# Altium schematic sheet MCU_BLE_Module.SchDoc(MCU_BLE_Module)
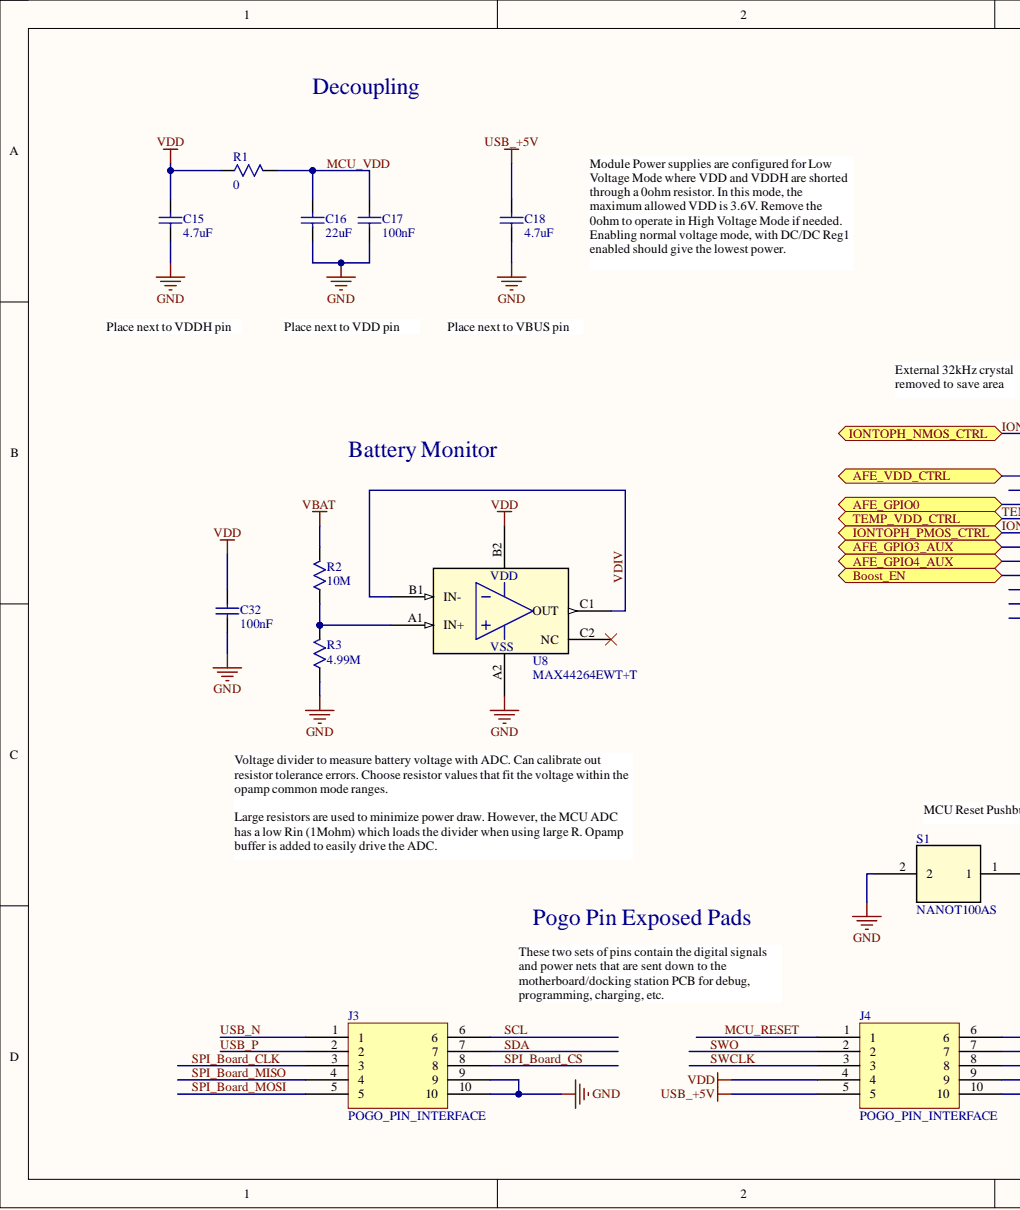
[diagram p0: MCU_BLE_Module.SchDoc, left]
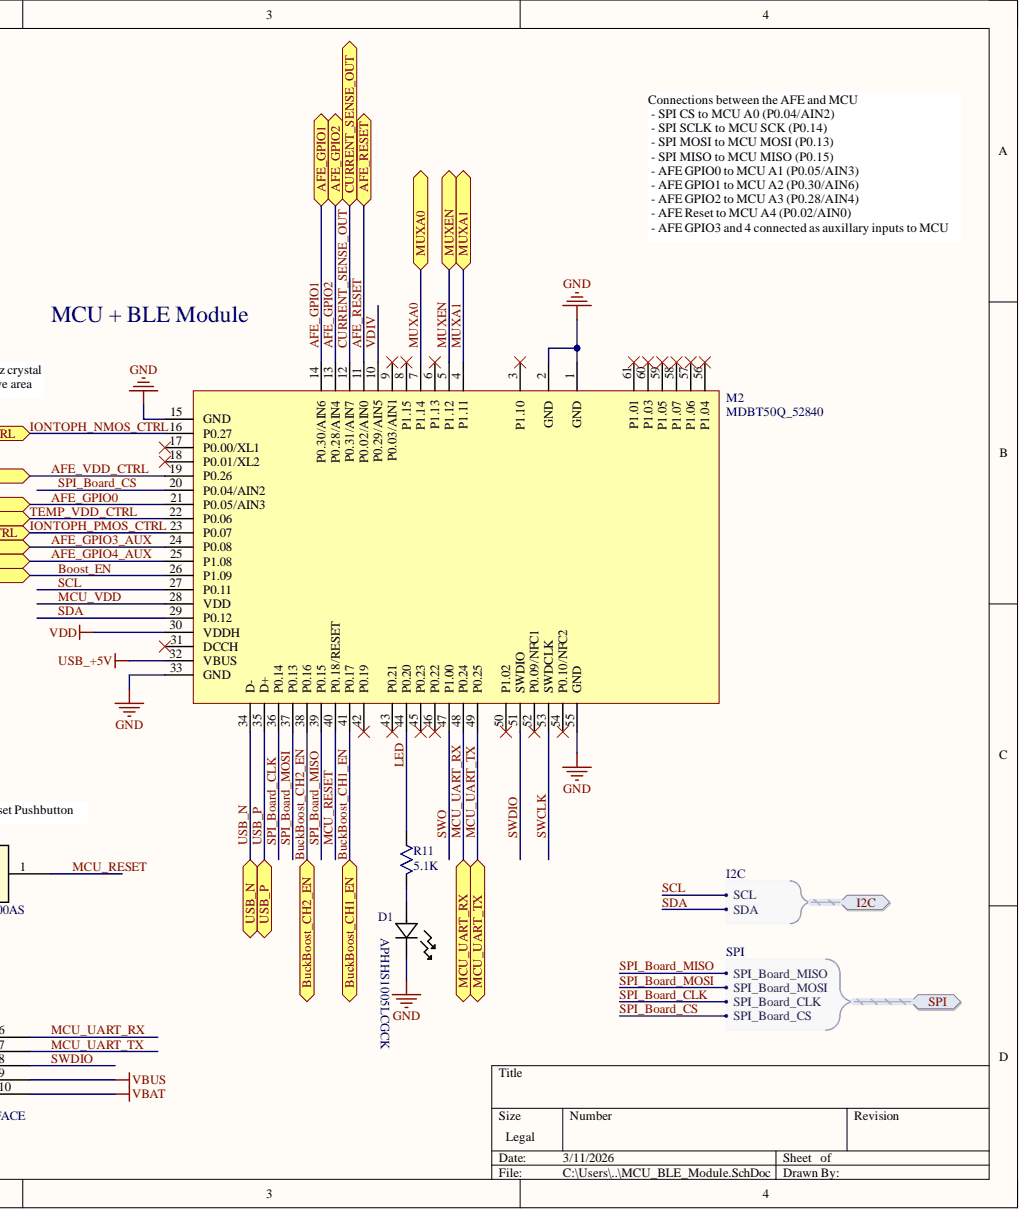
[diagram p1: MCU_BLE_Module.SchDoc, right]

## Parts
C15  value 4.7uF  [0201 cap]  (hidden comment: CAP)
C16  value 22uF  [0402-CAP]  (hidden comment: CAP)
C17  value 100nF  [0201 cap]  (hidden comment: CAP)
C18  value 4.7uF  [0201 cap]  (hidden comment: CAP)
C32  value 100nF  [0201 cap]  (hidden comment: CAP)
D1  APHHS1005LCGCK  [0402-LED]
J3  POGO_PIN_INTERFACE  [POGO_PIN_INTERFACE_10pin]
J4  POGO_PIN_INTERFACE  [POGO_PIN_INTERFACE_10pin]
M2  MDBT50Q_52840  [MDBT50Q]
R1  value 0  [0201 res]  (hidden comment: RES)
R2  value 10M  [0402-RES]  (hidden comment: RES)
R3  value 4.99M  [0402-RES]  (hidden comment: RES)
R11  value 5.1K  [0201 res]  (hidden comment: RES)
S1  NANOT100AS  [NANOT100AS_CNK-M]
U8  MAX44264EWT+T  [21-0217D_W61B1-1_MXM]

## Ports
AFE_GPIO0, AFE_GPIO1, AFE_GPIO2, AFE_GPIO3_AUX, AFE_GPIO4_AUX, AFE_VDD_CTRL, AFE_~{RESET}, Boost_EN, BuckBoost_CH1_EN, BuckBoost_CH2_EN, CURRENT_SENSE_OUT, I2C, I2C.SCL, I2C.SDA, IONTOPH_NMOS_CTRL, IONTOPH_PMOS_CTRL, MCU_UART_RX, MCU_UART_TX, MUXA0, MUXA1, MUXEN, SPI, SPI.SPI_Board_CLK, SPI.SPI_Board_CS, SPI.SPI_Board_MISO, SPI.SPI_Board_MOSI, TEMP_VDD_CTRL, USB_N, USB_P

## Nets
GND: C15.2 C16.2 C17.2 C18.2 C32.2 D1.1 J3.9 J3.10 M2.1(GND) M2.2(GND) M2.15(GND) M2.33(GND) M2.55(GND) R3.1 S1.2 U8.A2
USB_+5V: C18.1 J4.5 M2.32(VBUS)
VBAT: J4.10 R2.2
VBUS: J4.9
VDD: C15.1 C32.1 J4.4 M2.30(VDDH) R1.1 U8.B2
AFE_GPIO0: M2.21(P0.05/AIN3) ; port AFE_GPIO0
AFE_GPIO1: M2.14(P0.30/AIN6) ; port AFE_GPIO1
AFE_GPIO2: M2.13(P0.28/AIN4) ; port AFE_GPIO2
AFE_GPIO3_AUX: M2.24(P0.08) ; port AFE_GPIO3_AUX
AFE_GPIO4_AUX: M2.25(P1.08) ; port AFE_GPIO4_AUX
AFE_~{RESET}: M2.11(P0.02/AIN0) ; port AFE_~{RESET}
AFE_VDD_CTRL: M2.19(P0.26) ; port AFE_VDD_CTRL
Boost_EN: M2.26(P1.09) ; port Boost_EN
BuckBoost_CH1_EN: M2.41(P0.17) ; port BuckBoost_CH1_EN
BuckBoost_CH2_EN: M2.38(P0.16) ; port BuckBoost_CH2_EN
CURRENT_SENSE_OUT: M2.12(P0.31/AIN7) ; port CURRENT_SENSE_OUT
IONTOPH_NMOS_CTRL: M2.16(P0.27) ; port IONTOPH_NMOS_CTRL
IONTOPH_PMOS_CTRL: M2.23(P0.07) ; port IONTOPH_PMOS_CTRL
LED: M2.44(P0.20) R11.1
MCU_RESET: J4.1 M2.40(P0.18/RESET) S1.1
MCU_UART_RX: J4.6 M2.48(P0.24) ; port MCU_UART_RX
MCU_UART_TX: J4.7 M2.49(P0.25) ; port MCU_UART_TX
MCU_VDD: C16.1 C17.1 M2.28(VDD) R1.2
MUXA0: M2.7(P1.14) ; port MUXA0
MUXA1: M2.4(P1.11) ; port MUXA1
MUXEN: M2.5(P1.12) ; port MUXEN
SCL: J3.6 M2.27(P0.11) ; port I2C
SDA: J3.7 M2.29(P0.12) ; port I2C
SPI_Board_CLK: J3.3 M2.36(P0.14) ; port SPI
SPI_Board_CS: J3.8 M2.20(P0.04/AIN2) ; port SPI
SPI_Board_MISO: J3.4 M2.39(P0.15) ; port SPI
SPI_Board_MOSI: J3.5 M2.37(P0.13) ; port SPI
SWCLK: J4.3 M2.53(SWDCLK)
SWDIO: J4.8 M2.51(SWDIO)
SWO: J4.2 M2.47(P1.00)
TEMP_VDD_CTRL: M2.22(P0.06) ; port TEMP_VDD_CTRL
USB_N: J3.1 M2.34(D-) ; port USB_N
USB_P: J3.2 M2.35(D+) ; port USB_P
VDIV: M2.10(P0.29/AIN5) U8.B1(IN-) U8.C1(OUT)
NetD1_2: D1.2 R11.2
NetR2_1: R2.1 R3.2 U8.A1(IN+)

Not connected: M2 3(P1.10) 6(P1.13) 8(P1.15) 9(P0.03/AIN1) 17(P0.00/XL1) 18(P0.01/XL2) 31(DCCH) 42(P0.19) 43(P0.21) 45(P0.23) 46(P0.22) 50(P1.02) 52(P0.09/NFC1) 54(P0.10/NFC2) 56(P1.04) 57(P1.06) 58(P1.07) 59(P1.05) 60(P1.03) 61(P1.01); U8 C2(NC)

## Library data of the parts
C15-C18 C32 = CAP SMD: Multilayer Chip Capacitor; Designer: OMIGA; Component Kind: Standard; Library Name: Schlib.SchLib; Signal Integrity: CAP; Simulation: MLCC
D1 = APHHS1005LCGCK: Green LED 0402; Mfr_Name: Kingbright; Type: DEV; Component Kind: Standard; Library Name: Schlib.SchLib; Manufacturer_Part_Number: APHHS1005LCGCK; RefDes: RefDes; Copyright: Copyright (C) 2022 Ultra Librarian. All rights reserved.
R1-R3 R11 = RES SMD: Surface Mount Resistor; Designer: OMIGA; Component Kind: Standard; Library Name: Schlib.SchLib; Signal Integrity: RES; Simulation: RES SMD
S1 = NANOT100AS: Smallest Push Button Switch; Mfr_Name: C&K; Component Kind: Standard; Digi-Key_Part_Number_1: 108-NANOT100ASTR-ND; Digi-Key_Part_Number_2: 108-NANOT100ASCT-ND; Digi-Key_Part_Number_3: 108-NANOT100ASDKR-ND; Library Name: Schlib.SchLib; Manufacturer_Part_Number: NANOT100AS; RefDes: RefDes; Type: DEV; Copyright: Copyright (C) 2022 Ultra Librarian. All rights reserved.
U8 = MAX44264EWT+T: No Description Available; Mfr_Name: Maxim Integrated Products; Component Kind: Standard; Library Name: Schlib.SchLib; Manufacturer_Part_Number: MAX44264EWT+T; RefDes: RefDes; Type: DEV; Copyright: Copyright (C) 2022 Ultra Librarian. All rights reserved.
J3 J4 = POGO_PIN_INTERFACE: Component Kind: Standard; Library Name: Schlib.SchLib
M2 = MDBT50Q_52840: Component Kind: Standard; Description: MDBT50Q_52840; Library Name: Modules.SchLib
